# Altium schematic sheet Sheet1.SchDoc
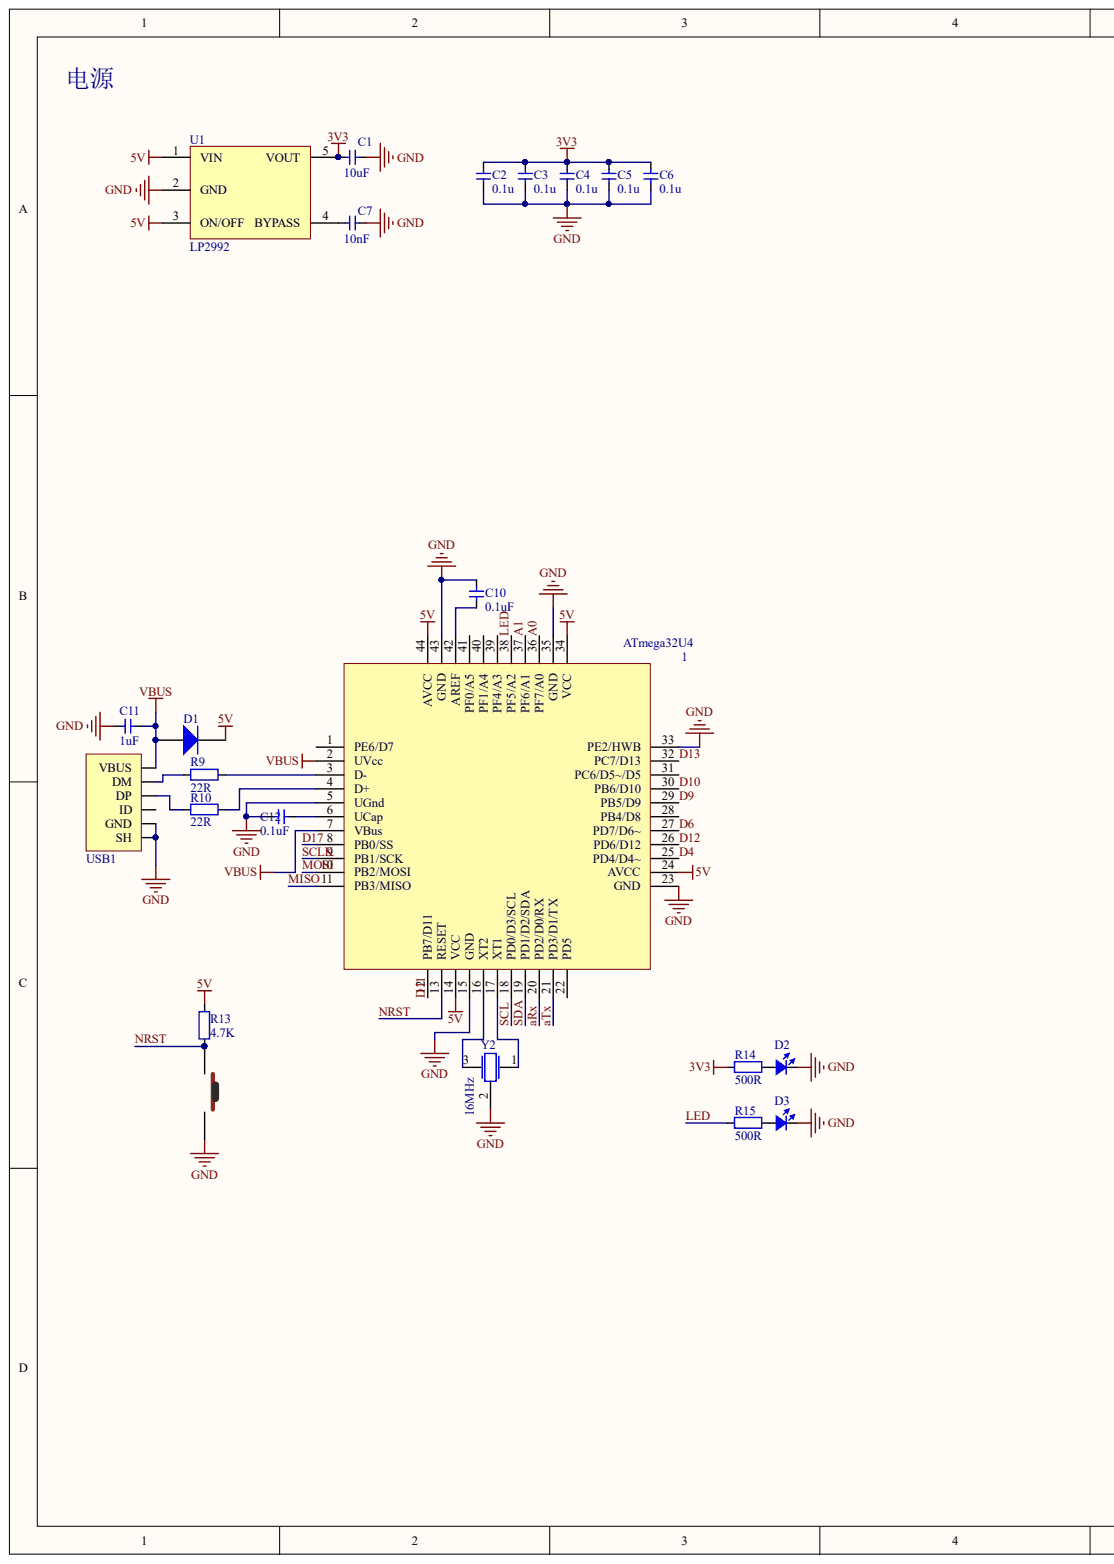
[diagram p0: Sheet1.SchDoc, left]
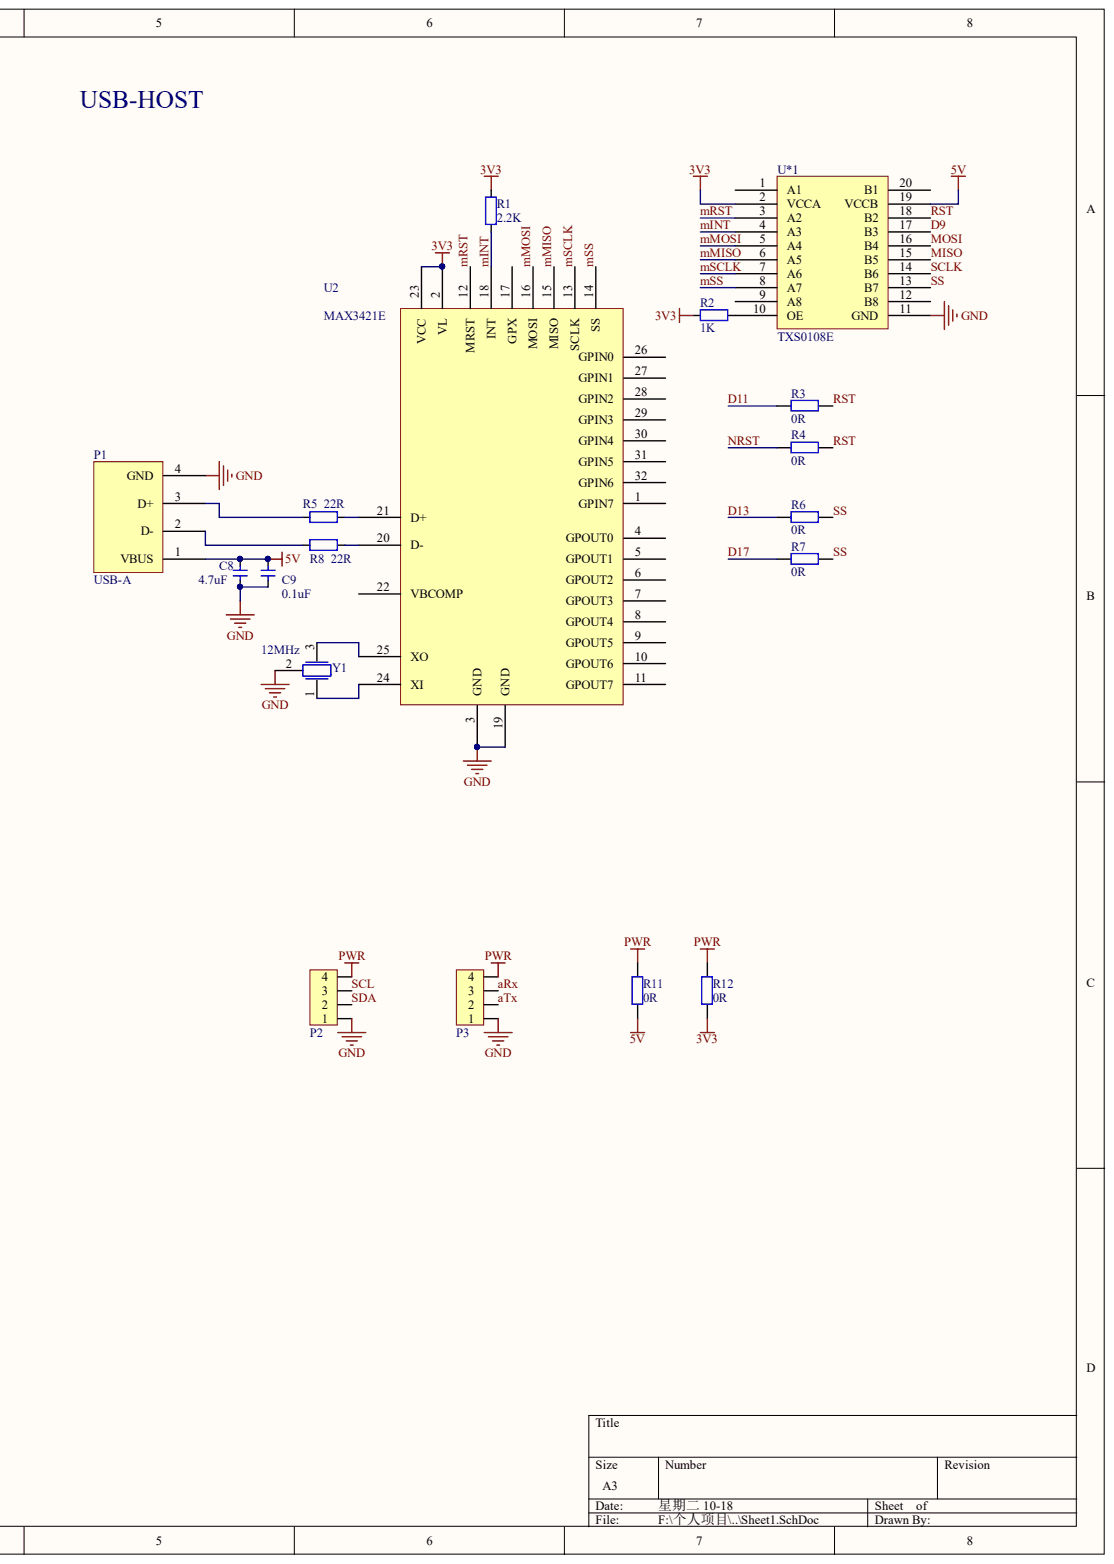
[diagram p1: Sheet1.SchDoc, right]

## Parts
1  ATmega32U4  [QFN-44]
C1  10uF  [0603-CAP]
C2  value 0.1u  [0603-CAP]  (hidden comment: 0.1uF)
C3  value 0.1u  [0603-CAP]  (hidden comment: 0.1uF)
C4  value 0.1u  [0603-CAP]  (hidden comment: 0.1uF)
C5  value 0.1u  [0603-CAP]  (hidden comment: 电容-0603)
C6  value 0.1u  [0603-CAP]  (hidden comment: 0.1uF)
C7  10nF  [0603-CAP]
C8  4.7uF  [0603-CAP]
C9  0.1uF  [0603-CAP]
C10  0.1uF  [0603-CAP]
C11  1uF  [0603-CAP]
C12  0.1uF  [0603-CAP]
D1  二极管-5819W  [SOD-123]  (value not drawn)
D2  LED-0603  [0603-LED-BLUE]  (value not drawn)
D3  LED-0603  [0603-LED-BLUE]  (value not drawn)
P1  USB-A  [USB-Short]
P2  HDR1x4_2.0  [接插件 4P]  (value not drawn)
P3  HDR1x4_2.0  [接插件 4P]  (value not drawn)
R1  2.2K  [0603-RES]
R2  1K  [0603-RES]
R3  value 0R  [0402-0R]  (hidden comment: 电阻-0R)
R4  value 0R  [0402-0R]  (hidden comment: 电阻-0R)
R5  22R  [0603-RES]
R6  value 0R  [0402-0R]  (hidden comment: 电阻-0R)
R7  value 0R  [0402-0R]  (hidden comment: 电阻-0R)
R8  22R  [0603-RES]
R9  22R  [0603-RES]
R10  22R  [0603-RES]
R11  value 0R  [0402-0R]  (hidden comment: 电阻-0R)
R12  value 0R  [0402-0R]  (hidden comment: 电阻-0R)
R13  4.7K  [0603-RES]
R14  500R  [0603-RES]
R15  500R  [0603-RES]
U1  LP2992  [SOT23-5]
U2  MAX3421E  [TQFP-32]
U*1  TXS0108E  [TSSOP-20]
USB1  USB-Micro  [USB_Micro-AB]  (value not drawn)
Y1  12MHz  [晶振-3P]
Y2  16MHz  [晶振-3P]
按键1  Reset  [SW-KEY2]  (value not drawn)

## Nets
3V3: C1.2 C2.1 C3.1 C4.1 C5.1 C6.1 R1.2 R2.1 R12.1 R14.1 U1.5(VOUT) U2.2(VL) U2.23(VCC) U*1.2(VCCA)
5V: 1.14(VCC) 1.24(AVCC) 1.34(VCC) 1.44(AVCC) C8.2 C9.2 D1.2 P1.1(VBUS) R11.1 R13.2 U1.1(VIN) U1.3(ON/OFF) U*1.19(VCCB)
GND: 1.5(UGnd) 1.15(GND) 1.23(GND) 1.33(PE2/HWB) 1.35(GND) 1.43(GND) C1.1 C2.2 C3.2 C4.2 C5.2 C6.2 C7.1 C8.1 C9.1 C10.2 C11.1 C12.1 D2.2 D3.2 P1.4(GND) P2.1 P3.1 U1.2(GND) U2.3(GND) U2.19(GND) U*1.11(GND) USB1.0(SH) USB1.5(GND) Y1.2 Y2.2 按键1.2
PWR: P2.4 P3.4 R11.2 R12.2
VBUS: 1.2(UVcc) 1.7(VBus) C11.2 D1.1 USB1.1(VBUS)
A0: 1.36(PF7/A0)
A1: 1.37(PF6/A1)
ARX: 1.20(PD2/D0/RX) P3.3
ATX: 1.21(PD3/D1/TX) P3.2
D4: 1.25(PD4/D4~)
D6: 1.27(PD7/D6~)
D9: 1.29(PB5/D9) U*1.17(B3)
D10: 1.30(PB6/D10)
D11: 1.12(PB7/D11) R3.1
D12: 1.26(PD6/D12)
D13: 1.32(PC7/D13) R6.1
D17: 1.8(PB0/SS) R7.1
LED: 1.38(PF5/A2) R15.1
MINT: R1.1 U2.18(INT) U*1.4(A3)
MISO: 1.11(PB3/MISO) U*1.15(B5)
MMISO: U2.15(MISO) U*1.6(A5)
MMOSI: U2.16(MOSI) U*1.5(A4)
MOSI: 1.10(PB2/MOSI) U*1.16(B4)
MRST: U2.12(MRST) U*1.3(A2)
MSCLK: U2.13(SCLK) U*1.7(A6)
MSS: U2.14(SS) U*1.8(A7)
NRST: 1.13(RESET) R4.1 R13.1 按键1.1
RST: R3.2 R4.2 U*1.18(B2)
SCL: 1.18(PD0/D3/SCL) P2.3
SCLK: 1.9(PB1/SCK) U*1.14(B6)
SDA: 1.19(PD1/D2/SDA) P2.2
SS: R6.2 R7.2 U*1.13(B7)
Net1_3: 1.3(D-) R9.2
Net1_4: 1.4(D+) R10.2
Net1_6: 1.6(UCap) C12.2
Net1_16: 1.16(XT2) Y2.3
Net1_17: 1.17(XT1) Y2.1
Net1_42: 1.42(AREF) C10.1
NetC7_2: C7.2 U1.4(BYPASS)
NetD2_1: D2.1 R14.2
NetD3_1: D3.1 R15.2
NetP1_2: P1.2(D-) R8.1
NetP1_3: P1.3(D+) R5.1
NetR2_2: R2.2 U*1.10(OE)
NetR5_2: R5.2 U2.21(D+)
NetR8_2: R8.2 U2.20(D-)
NetR9_1: R9.1 USB1.2(DM)
NetR10_1: R10.1 USB1.3(DP)
NetU2_24: U2.24(XI) Y1.1
NetU2_25: U2.25(XO) Y1.3

Not connected: 1 1(PE6/D7) 22(PD5) 28(PB4/D8) 31(PC6/D5~/D5) 39(PF4/A3) 40(PF1/A4) 41(PF0/A5); U2 1(GPIN7) 4(GPOUT0) 5(GPOUT1) 6(GPOUT2) 7(GPOUT3) 8(GPOUT4) 9(GPOUT5) 10(GPOUT6) 11(GPOUT7) 17(GPX) 22(VBCOMP) 26(GPIN0) 27(GPIN1) 28(GPIN2) 29(GPIN3) 30(GPIN4) 31(GPIN5) 32(GPIN6); U*1 1(A1) 9(A8) 12(B8) 20(B1); USB1 4(ID)

## Library data of the parts
1 = ATmega32U4: MCU; Component Kind: Standard; Library Name: mLib.SchLib
C1-C7 = 电容-0603: Capacitor; Component Kind: Standard; Library Name: mLib.SchLib
  C1: Value: 10uF
  C7: Value: 10nF
C8-C12 = 电容-0603: Capacitor; Value: 0.1u; Component Kind: Standard; Library Name: mLib.SchLib
D1 = 二极管-5819W: 40V; Component Kind: Standard; Library Name: mLib.SchLib
D2 D3 = LED-0603: LED; Component Kind: Standard; Library Name: mLib.SchLib
P2 P3 = HDR1x4_2.0: Header; Component Kind: Standard; Library Name: mLib.SchLib
R1 R2 = 电阻-0603: Resistor; Value: 22R; Component Kind: Standard; Library Name: mLib.SchLib
R3 R4 R6 R7 R11 R12 = 电阻-0R: Resistor; Component Kind: Standard; LatestRevisionDate: 17-Jul-2002; LatestRevisionNote: Re-released for DXP Platform.; Library Name: mLib.SchLib; PackageDescription: Axial Device, Thru-Hole; 2 Leads; 0.4 in Pin Spacing; PackageReference: AXIAL-0.4; Published: 8-Jun-2000; Publisher: Altium Limited
R5 R8-R10 R13-R15 = 电阻-0603: Resistor; Component Kind: Standard; Library Name: mLib.SchLib
  R5 R8-R10: Value: 22R
  R13: Value: 4.7K
  R14 R15: Value: 500R
U1 = LP2992: LDO; Component Kind: Standard; Library Name: mLib.SchLib
U*1 = TXS0108E: 电平转换芯片; Component Kind: Standard; Library Name: mLib.SchLib
USB1 = USB-Micro: USB; Component Kind: Standard; Library Name: mLib.SchLib
Y1 Y2 = 晶振-3Pin: 村田贴片; Component Kind: Standard; Library Name: mLib.SchLib
按键1 = 按键-4Pin: 贴片轻触; Component Kind: Standard; Library Name: mLib.SchLib
P1 = USB-A: Component Kind: Standard; Library Name: mLib.SchLib
U2 = MAX3421E: Component Kind: Standard; Library Name: myLib.SchLib
